# Altium schematic sheet Microscope_Lamp.SchDoc(Microscope_Lamp)
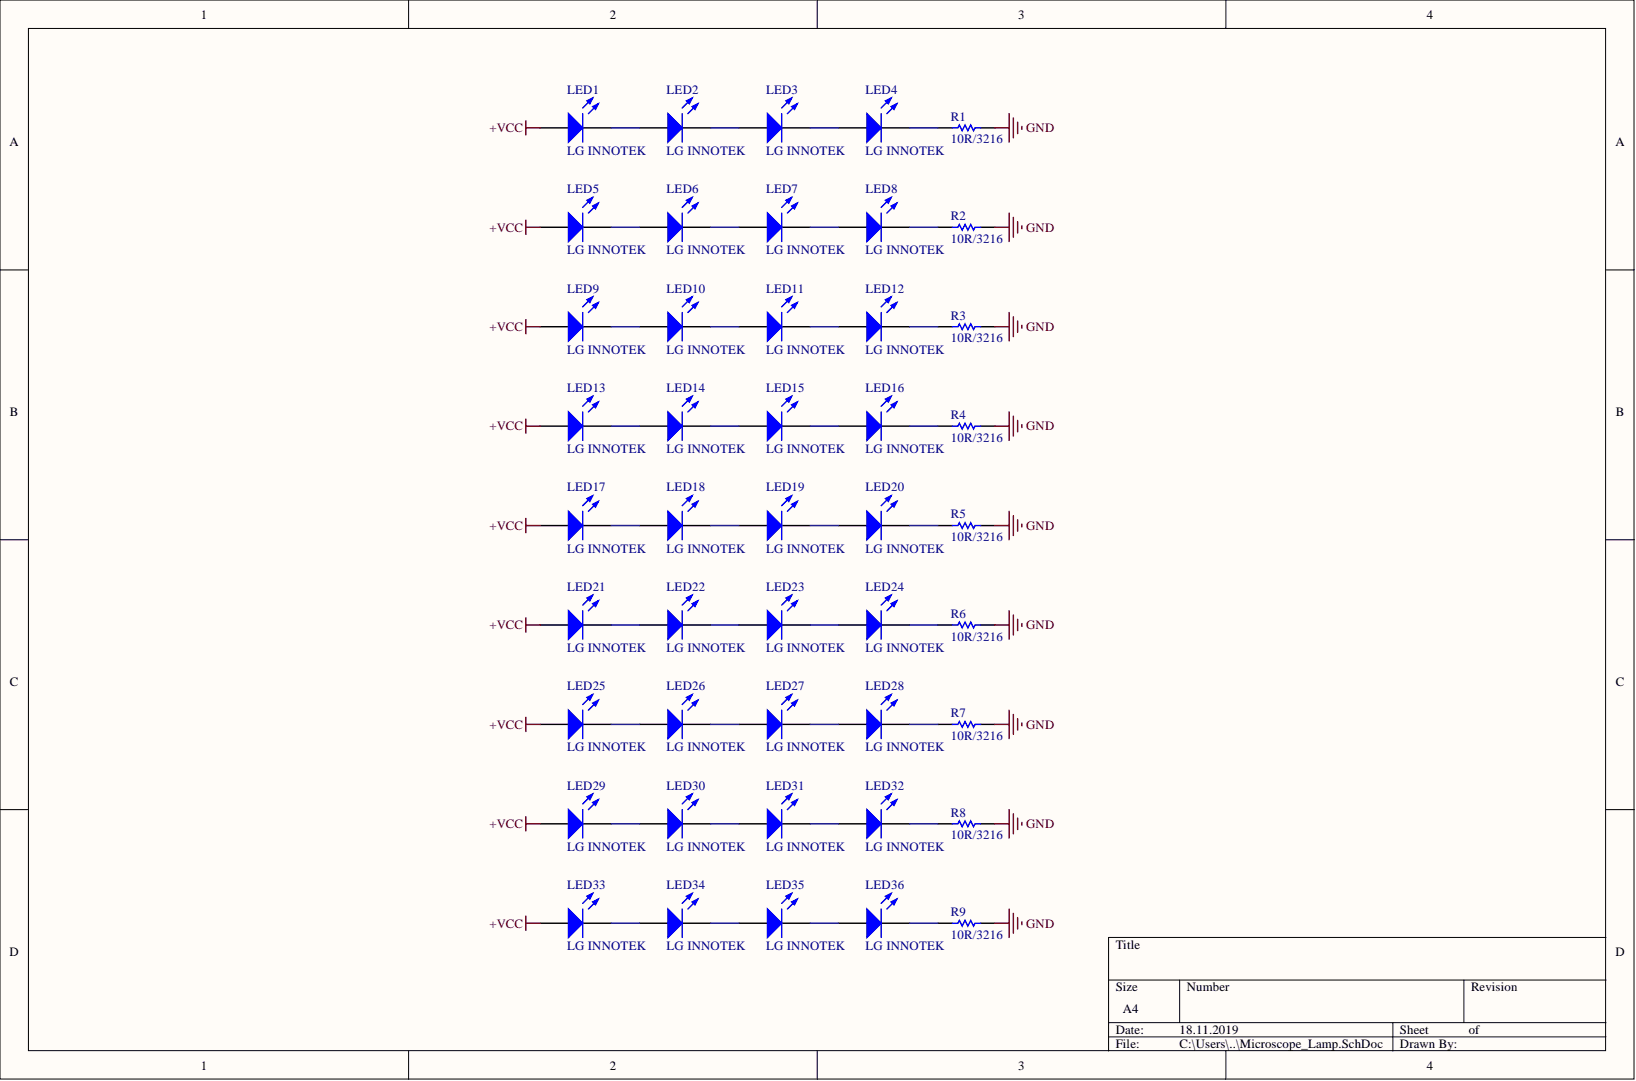
[diagram p0: Microscope_Lamp.SchDoc]

## Parts
LED1  LG INNOTEK  [LED_5630]
LED2  LG INNOTEK  [LED_5630]
LED3  LG INNOTEK  [LED_5630]
LED4  LG INNOTEK  [LED_5630]
LED5  LG INNOTEK  [LED_5630]
LED6  LG INNOTEK  [LED_5630]
LED7  LG INNOTEK  [LED_5630]
LED8  LG INNOTEK  [LED_5630]
LED9  LG INNOTEK  [LED_5630]
LED10  LG INNOTEK  [LED_5630]
LED11  LG INNOTEK  [LED_5630]
LED12  LG INNOTEK  [LED_5630]
LED13  LG INNOTEK  [LED_5630]
LED14  LG INNOTEK  [LED_5630]
LED15  LG INNOTEK  [LED_5630]
LED16  LG INNOTEK  [LED_5630]
LED17  LG INNOTEK  [LED_5630]
LED18  LG INNOTEK  [LED_5630]
LED19  LG INNOTEK  [LED_5630]
LED20  LG INNOTEK  [LED_5630]
LED21  LG INNOTEK  [LED_5630]
LED22  LG INNOTEK  [LED_5630]
LED23  LG INNOTEK  [LED_5630]
LED24  LG INNOTEK  [LED_5630]
LED25  LG INNOTEK  [LED_5630]
LED26  LG INNOTEK  [LED_5630]
LED27  LG INNOTEK  [LED_5630]
LED28  LG INNOTEK  [LED_5630]
LED29  LG INNOTEK  [LED_5630]
LED30  LG INNOTEK  [LED_5630]
LED31  LG INNOTEK  [LED_5630]
LED32  LG INNOTEK  [LED_5630]
LED33  LG INNOTEK  [LED_5630]
LED34  LG INNOTEK  [LED_5630]
LED35  LG INNOTEK  [LED_5630]
LED36  LG INNOTEK  [LED_5630]
R1  10R/3216  [RES3216X07N]
R2  10R/3216  [RES3216X07N]
R3  10R/3216  [RES3216X07N]
R4  10R/3216  [RES3216X07N]
R5  10R/3216  [RES3216X07N]
R6  10R/3216  [RES3216X07N]
R7  10R/3216  [RES3216X07N]
R8  10R/3216  [RES3216X07N]
R9  10R/3216  [RES3216X07N]

## Nets
+VCC: LED1.1 LED5.1 LED9.1 LED13.1 LED17.1 LED21.1 LED25.1 LED29.1 LED33.1
GND: R1.2 R2.2 R3.2 R4.2 R5.2 R6.2 R7.2 R8.2 R9.2
NetLED1_2: LED1.2 LED2.1
NetLED2_2: LED2.2 LED3.1
NetLED3_2: LED3.2 LED4.1
NetLED4_2: LED4.2 R1.1
NetLED5_2: LED5.2 LED6.1
NetLED6_2: LED6.2 LED7.1
NetLED7_2: LED7.2 LED8.1
NetLED8_2: LED8.2 R2.1
NetLED9_2: LED9.2 LED10.1
NetLED10_2: LED10.2 LED11.1
NetLED11_2: LED11.2 LED12.1
NetLED12_2: LED12.2 R3.1
NetLED13_2: LED13.2 LED14.1
NetLED14_2: LED14.2 LED15.1
NetLED15_2: LED15.2 LED16.1
NetLED16_2: LED16.2 R4.1
NetLED17_2: LED17.2 LED18.1
NetLED18_2: LED18.2 LED19.1
NetLED19_2: LED19.2 LED20.1
NetLED20_2: LED20.2 R5.1
NetLED21_2: LED21.2 LED22.1
NetLED22_2: LED22.2 LED23.1
NetLED23_2: LED23.2 LED24.1
NetLED24_2: LED24.2 R6.1
NetLED25_2: LED25.2 LED26.1
NetLED26_2: LED26.2 LED27.1
NetLED27_2: LED27.2 LED28.1
NetLED28_2: LED28.2 R7.1
NetLED29_2: LED29.2 LED30.1
NetLED30_2: LED30.2 LED31.1
NetLED31_2: LED31.2 LED32.1
NetLED32_2: LED32.2 R8.1
NetLED33_2: LED33.2 LED34.1
NetLED34_2: LED34.2 LED35.1
NetLED35_2: LED35.2 LED36.1
NetLED36_2: LED36.2 R9.1

## Library data of the parts
LED1-LED36 = LED1: Typical RED GaAs LED; Simulation: LED1; Library Name: Miscellaneous Devices.IntLib; Component Kind: Standard
R1-R9 = Res1: Resistor; Simulation: RESISTOR; Signal Integrity: Res; Library Name: Miscellaneous Devices.IntLib; Component Kind: Standard
